# Altium schematic sheet LED Matrix.SchDoc("LED Matrix")
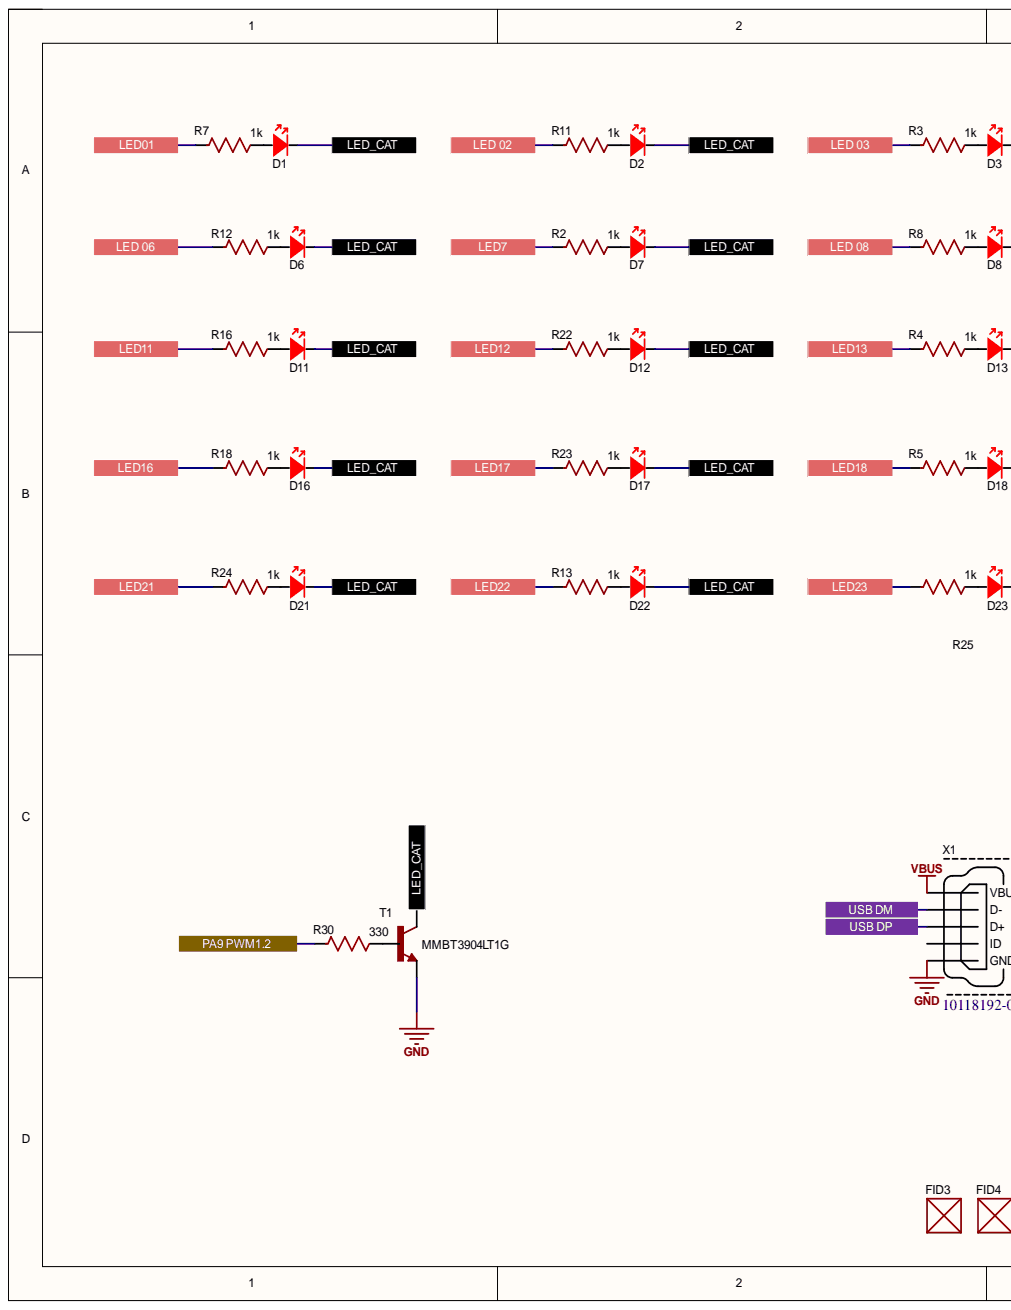
[diagram p0: LED Matrix.SchDoc, left]
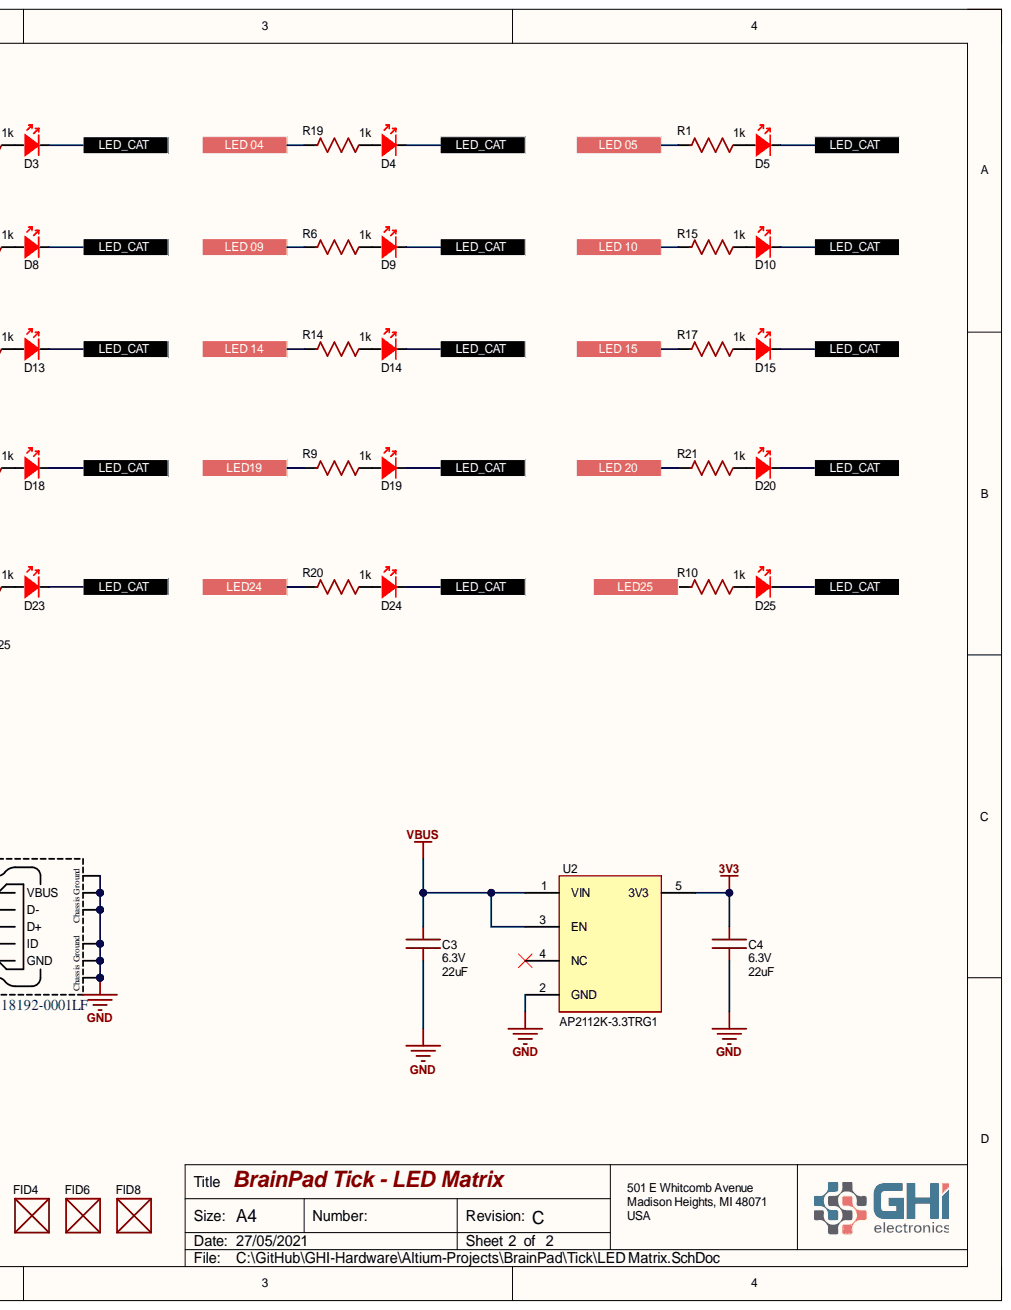
[diagram p1: LED Matrix.SchDoc, right]

## Parts
C3  value 22uF  Capacitance: 22uF  Voltage: 6.3V  [C0805 L]  (hidden comment: 0805 Cap 22uF)
C4  value 22uF  Capacitance: 22uF  Voltage: 6.3V  [C0805 L]  (hidden comment: 0805 Cap 22uF)
D1  0603 LED Red  [0603 LED Red]  (value not drawn)
D2  0603 LED Red  [0603 LED Red]  (value not drawn)
D3  0603 LED Red  [0603 LED Red]  (value not drawn)
D4  0603 LED Red  [0603 LED Red]  (value not drawn)
D5  0603 LED Red  [0603 LED Red]  (value not drawn)
D6  0603 LED Red  [0603 LED Red]  (value not drawn)
D7  0603 LED Red  [0603 LED Red]  (value not drawn)
D8  0603 LED Red  [0603 LED Red]  (value not drawn)
D9  0603 LED Red  [0603 LED Red]  (value not drawn)
D10  0603 LED Red  [0603 LED Red]  (value not drawn)
D11  0603 LED Red  [0603 LED Red]  (value not drawn)
D12  0603 LED Red  [0603 LED Red]  (value not drawn)
D13  0603 LED Red  [0603 LED Red]  (value not drawn)
D14  0603 LED Red  [0603 LED Red]  (value not drawn)
D15  0603 LED Red  [0603 LED Red]  (value not drawn)
D16  0603 LED Red  [0603 LED Red]  (value not drawn)
D17  0603 LED Red  [0603 LED Red]  (value not drawn)
D18  0603 LED Red  [0603 LED Red]  (value not drawn)
D19  0603 LED Red  [0603 LED Red]  (value not drawn)
D20  0603 LED Red  [0603 LED Red]  (value not drawn)
D21  0603 LED Red  [0603 LED Red]  (value not drawn)
D22  0603 LED Red  [0603 LED Red]  (value not drawn)
D23  0603 LED Red  [0603 LED Red]  (value not drawn)
D24  0603 LED Red  [0603 LED Red]  (value not drawn)
D25  0603 LED Red  [0603 LED Red]  (value not drawn)
FID3  Fiducial 40 Mil  [Fiducial 40 Mil]  (value not drawn)
FID4  Fiducial 40 Mil  [Fiducial 40 Mil]  (value not drawn)
FID6  Fiducial 40 Mil  [Fiducial 40 Mil]  (value not drawn)
FID8  Fiducial 40 Mil  [Fiducial 40 Mil]  (value not drawn)
R1  value 1k  Resistance: 1k  [R0402]  (hidden comment: 0402 Res 0)
R2  value 1k  Resistance: 1k  [R0402]  (hidden comment: 0402 Res 0)
R3  value 1k  Resistance: 1k  [R0402]  (hidden comment: 0402 Res 0)
R4  value 1k  Resistance: 1k  [R0402]  (hidden comment: 0402 Res 0)
R5  value 1k  Resistance: 1k  [R0402]  (hidden comment: 0402 Res 0)
R6  value 1k  Resistance: 1k  [R0402]  (hidden comment: 0402 Res 0)
R7  value 1k  Resistance: 1k  [R0402]  (hidden comment: 0402 Res 0)
R8  value 1k  Resistance: 1k  [R0402]  (hidden comment: 0402 Res 0)
R9  value 1k  Resistance: 1k  [R0402]  (hidden comment: 0402 Res 0)
R10  value 1k  Resistance: 1k  [R0402]  (hidden comment: 0402 Res 0)
R11  value 1k  Resistance: 1k  [R0402]  (hidden comment: 0402 Res 0)
R12  value 1k  Resistance: 1k  [R0402]  (hidden comment: 0402 Res 0)
R13  value 1k  Resistance: 1k  [R0402]  (hidden comment: 0402 Res 0)
R14  value 1k  Resistance: 1k  [R0402]  (hidden comment: 0402 Res 0)
R15  value 1k  Resistance: 1k  [R0402]  (hidden comment: 0402 Res 0)
R16  value 1k  Resistance: 1k  [R0402]  (hidden comment: 0402 Res 0)
R17  value 1k  Resistance: 1k  [R0402]  (hidden comment: 0402 Res 0)
R18  value 1k  Resistance: 1k  [R0402]  (hidden comment: 0402 Res 0)
R19  value 1k  Resistance: 1k  [R0402]  (hidden comment: 0402 Res 0)
R20  value 1k  Resistance: 1k  [R0402]  (hidden comment: 0402 Res 0)
R21  value 1k  Resistance: 1k  [R0402]  (hidden comment: 0402 Res 0)
R22  value 1k  Resistance: 1k  [R0402]  (hidden comment: 0402 Res 0)
R23  value 1k  Resistance: 1k  [R0402]  (hidden comment: 0402 Res 0)
R24  value 1k  Resistance: 1k  [R0402]  (hidden comment: 0402 Res 0)
R25  value 1k  Resistance: 1k  [R0402]  (hidden comment: 0402 Res 0)
R30  value 330  [R0402]  (hidden comment: 0402 Res 330)
T1  3904  value MMBT3904LT1G  [SOT23]
U2  AP2112K-3.3TRG1  [SOT95P280X100-5N]
X1  10118192-0001LF  [10118192-0001LF (Micro USB B Amphenol FCI)]

## Ports
LED01, LED7, LED11, LED12, LED13, LED16, LED17, LED18, LED19, LED21, LED22, LED23, LED24, LED25, LED 02, LED 03, LED 04, LED 05, LED 06, LED 08, LED 09, LED 10, LED 14, LED 15, LED 20, LED_CAT, PA9 PWM1.2, USB DM, USB DP

## Nets
3V3: C4.2 U2.5(3V3)
GND: C3.1 C4.1 T1.2 U2.2(GND) X1.5(GND) X1.CGND1 X1.CGND2 X1.CGND3 X1.CGND4 X1.CGND5 X1.CGND6
VBUS: C3.2 U2.1(VIN) U2.3(EN) X1.1(VBUS)
LED01: R7.1 ; port LED01
LED7: R2.1 ; port LED7
LED11: R16.1 ; port LED11
LED12: R22.1 ; port LED12
LED13: R4.1 ; port LED13
LED16: R18.1 ; port LED16
LED17: R23.1 ; port LED17
LED18: R5.1 ; port LED18
LED19: R9.1 ; port LED19
LED21: R24.1 ; port LED21
LED22: R13.1 ; port LED22
LED23: R25.1 ; port LED23
LED24: R20.1 ; port LED24
LED25: R10.1 ; port LED25
LED 02: R11.1 ; port LED 02
LED 03: R3.1 ; port LED 03
LED 04: R19.1 ; port LED 04
LED 05: R1.1 ; port LED 05
LED 06: R12.1 ; port LED 06
LED 08: R8.1 ; port LED 08
LED 09: R6.1 ; port LED 09
LED 10: R15.1 ; port LED 10
LED 14: R14.1 ; port LED 14
LED 15: R17.1 ; port LED 15
LED 20: R21.1 ; port LED 20
LED_CAT: D1.1 D2.1 D3.1 D4.1 D5.1 D6.1 D7.1 D8.1 D9.1 D10.1 D11.1 D12.1 D13.1 D14.1 D15.1 D16.1 D17.1 D18.1 D19.1 D20.1 D21.1 D22.1 D23.1 D24.1 D25.1 T1.3 ; port LED_CAT
PA9 PWM1.2: R30.1 ; port PA9 PWM1.2
USB DM: X1.2(D-) ; port USB DM
USB DP: X1.3(D+) ; port USB DP
NetD1_2: D1.2 R7.2
NetD2_2: D2.2 R11.2
NetD3_2: D3.2 R3.2
NetD4_2: D4.2 R19.2
NetD5_2: D5.2 R1.2
NetD6_2: D6.2 R12.2
NetD7_2: D7.2 R2.2
NetD8_2: D8.2 R8.2
NetD9_2: D9.2 R6.2
NetD10_2: D10.2 R15.2
NetD11_2: D11.2 R16.2
NetD12_2: D12.2 R22.2
NetD13_2: D13.2 R4.2
NetD14_2: D14.2 R14.2
NetD15_2: D15.2 R17.2
NetD16_2: D16.2 R18.2
NetD17_2: D17.2 R23.2
NetD18_2: D18.2 R5.2
NetD19_2: D19.2 R9.2
NetD20_2: D20.2 R21.2
NetD21_2: D21.2 R24.2
NetD22_2: D22.2 R13.2
NetD23_2: D23.2 R25.2
NetD24_2: D24.2 R20.2
NetD25_2: D25.2 R10.2
NetR30_2: R30.2 T1.1
NetU2_4: U2.4(NC)
NetX1_4: X1.4(ID)

## Library data of the parts
C3 C4 = 0805 Cap 22uF: 22uF ±20% 6.3V X5R 0805 Multilayer Ceramic Capacitors MLCC - SMD/SMT RoHS; Mfr.Part #: CL21A226MQCLRNC; Category: Ceramic; Component Kind: Standard; LCSC Part #: C307530; Package: 0805; Tolerance: ±20%; Library Name: GHI-Passives.SchLib
D1-D25 = 0603 LED Red: Red 631nm 0603 Light Emitting Diodes (LED) RoHS; Manufacturer: Lite-On; Mfr.Part #: LTST-C193KRKT-5A; Color: Red; Component Kind: Standard; LCSC Part #: C157741; Package: 0603; Value: 0603 LED Red; Library Name: GHI-Opto.SchLib
R1-R25 R30 = 0402 Res 0: ±5% 1/16W 0402 Chip Resistor - Surface Mount RoHS; Manufacturer: YAGEO; Voltage Rating: *V; Component Kind: Standard; Package: 0402; Power Rating: 1/16W; Resistance Tolerance: ±5%; Library Name: GHI-Passives.SchLib
  R30: Resistance: 330
T1 = MMBT3904LT1G: NPN 200mA 40V 300mW SOT-23(SOT-23-3) Transistors (NPN/PNP) RoHS; Manufacturer: ON Semicon; Component Kind: Standard; LCSC Part #: C81464; Mfr.Part #: MMBT3904LT1G; Package: SOT-23(SOT-23-3); Library Name: GHI-Semiconductors.SchLib
U2 = AP2112K-3.3TRG1: Fixed 6V 3.3V 600mA SOT-25 Low Dropout Regulators(LDO) RoHS; Manufacturer: Diodes Incorporated; Component Kind: Standard; ComponentLink1Description: http://www.diodes.com/_files/datasheets/AP2112.pdf; ComponentLink1URL: http://www.diodes.com/_files/datasheets/AP2112.pdf; LCSC Part #: C51118; Mfr.Part #: AP2112K-3.3TRG1; Package: SOT-25; Value: AP2112K-3.3TRG1; Library Name: GHI-Power.SchLib
X1 = 10118192-0001LF: Amphenol FCI Micro USB Type B Complete Surface Mount (SMT) no guide holes; Component Kind: Standard; Library Name: GHI.SchLib
FID3 FID4 FID6 FID8 = Fiducial 40 Mil: Component Kind: Standard; Library Name: GHI-Misc.SchLib
